# Altium schematic sheet 2_USB.SchDoc(2_USB)
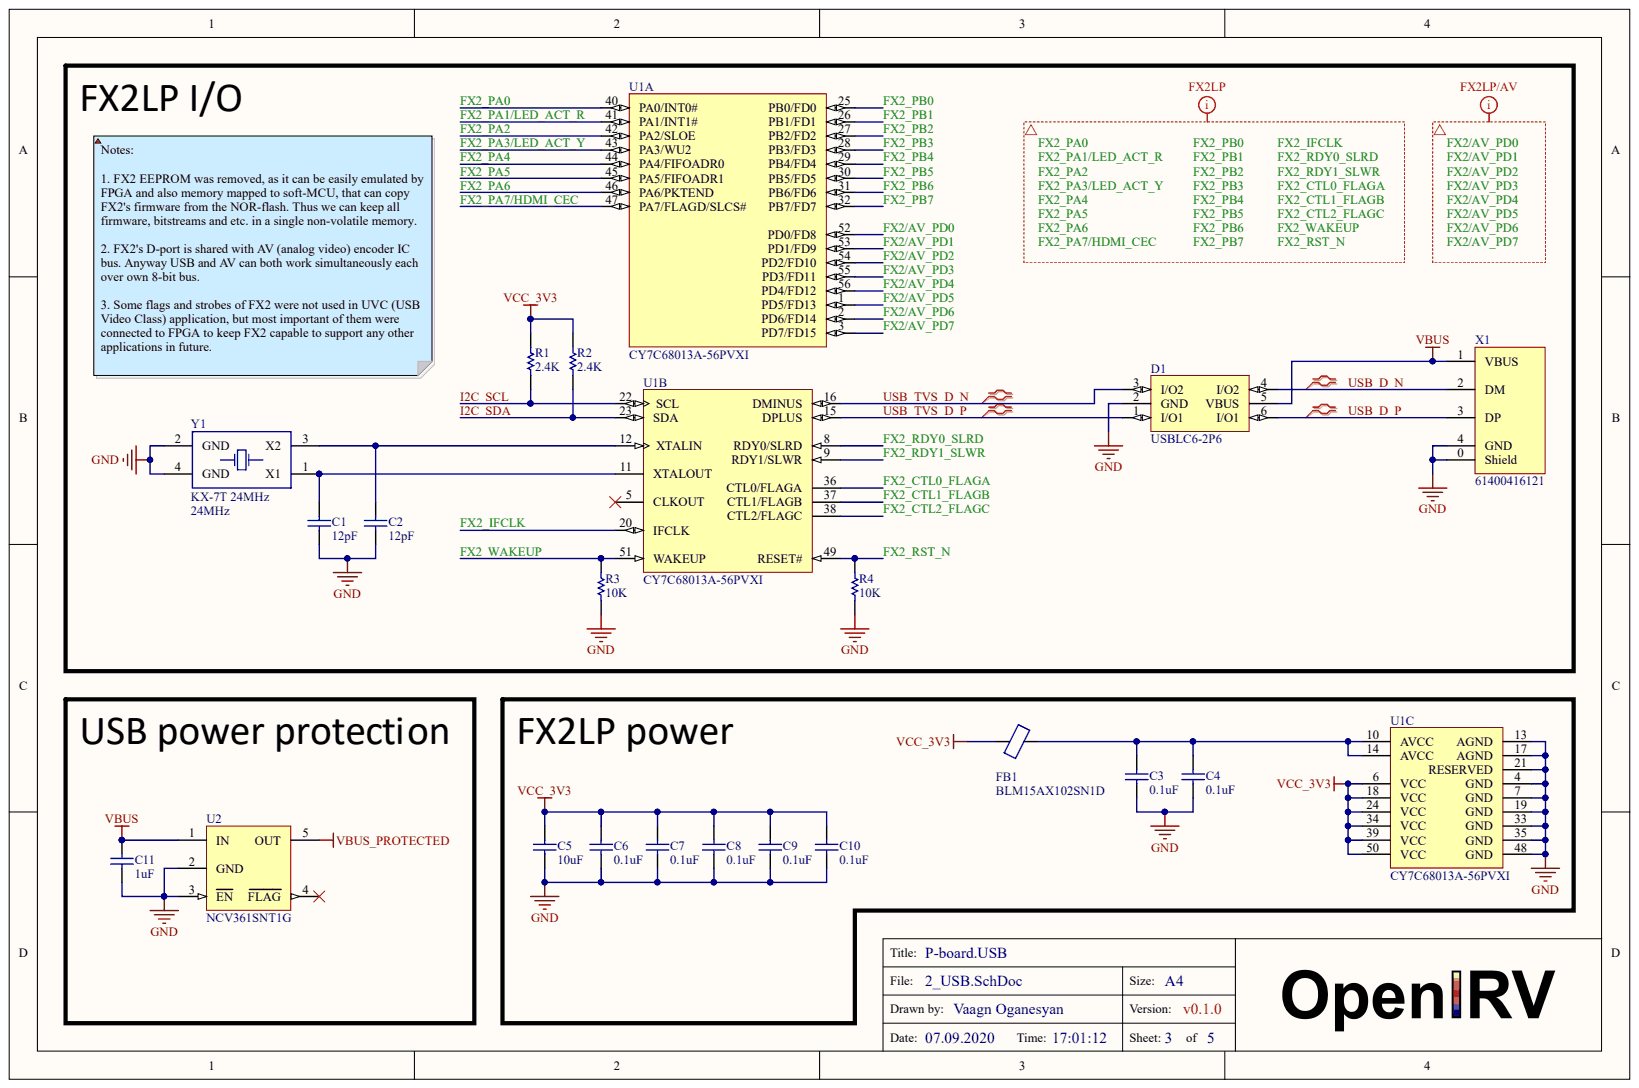
[diagram p0: 2_USB.SchDoc]

## Parts
C1  12pF  [C0402[1005]]
C2  12pF  [C0402[1005]]
C3  0.1uF  [C0402[1005]]
C4  0.1uF  [C0402[1005]]
C5  10uF  [C0805[2012]]
C6  0.1uF  [C0402[1005]]
C7  0.1uF  [C0402[1005]]
C8  0.1uF  [C0402[1005]]
C9  0.1uF  [C0402[1005]]
C10  0.1uF  [C0402[1005]]
C11  1uF  [C0603[1608]]
D1  USBLC6-2P6  [USBLC6-2P6]
FB1  BLM15AX102SN1D  [L0402[1005]]
R1  2.4K  [R0402[1005]]
R2  2.4K  [R0402[1005]]
R3  10K  [R0402[1005]]
R4  10K  [R0402[1005]]
U1  CY7C68013A-56PVXI  [CY7C68013A-56PVXI]  (parts A,B,C)
U2  NCV361SNT1G  [NCV361SNT1G]
X1  61400416121  [61400416121]
Y1  KX-7T 24MHz  Frequency: 24MHz  [Crystal[3225]]

## Nets
GND: C1.2 C2.2 C3.2 C4.2 C5.1 C6.2 C7.2 C8.2 C9.2 C10.2 C11.2 D1.2(GND) R3.1 R4.1 U1.4(GND) U1.7(GND) U1.13(AGND) U1.17(AGND) U1.19(GND) U1.21(RESERVED) U1.33(GND) U1.35(GND) U1.48(GND) U2.2(GND) U2.3(EN) X1.0(Shield) X1.4(GND) Y1.2(GND) Y1.4(GND)
VBUS: C11.1 D1.5(VBUS) U2.1(IN) X1.1(VBUS)
VBUS_PROTECTED: U2.5(OUT)
VCC_3V3: C5.2 C6.1 C7.1 C8.1 C9.1 C10.1 FB1.1 R1.2 R2.2 U1.6(VCC) U1.18(VCC) U1.24(VCC) U1.34(VCC) U1.39(VCC) U1.50(VCC)
FX2/AV_PD0: U1.52(PD0/FD8)
FX2/AV_PD1: U1.53(PD1/FD9)
FX2/AV_PD2: U1.54(PD2/FD10)
FX2/AV_PD3: U1.55(PD3/FD11)
FX2/AV_PD4: U1.56(PD4/FD12)
FX2/AV_PD5: U1.1(PD5/FD13)
FX2/AV_PD6: U1.2(PD6/FD14)
FX2/AV_PD7: U1.3(PD7/FD15)
FX2_CTL0_FLAGA: U1.36(CTL0/FLAGA)
FX2_CTL1_FLAGB: U1.37(CTL1/FLAGB)
FX2_CTL2_FLAGC: U1.38(CTL2/FLAGC)
FX2_IFCLK: U1.20(IFCLK)
FX2_PA0: U1.40(PA0/INT0#)
FX2_PA1/LED_ACT_R: U1.41(PA1/INT1#)
FX2_PA2: U1.42(PA2/SLOE)
FX2_PA3/LED_ACT_Y: U1.43(PA3/WU2)
FX2_PA4: U1.44(PA4/FIFOADR0)
FX2_PA5: U1.45(PA5/FIFOADR1)
FX2_PA6: U1.46(PA6/PKTEND)
FX2_PA7/HDMI_CEC: U1.47(PA7/FLAGD/SLCS#)
FX2_PB0: U1.25(PB0/FD0)
FX2_PB1: U1.26(PB1/FD1)
FX2_PB2: U1.27(PB2/FD2)
FX2_PB3: U1.28(PB3/FD3)
FX2_PB4: U1.29(PB4/FD4)
FX2_PB5: U1.30(PB5/FD5)
FX2_PB6: U1.31(PB6/FD6)
FX2_PB7: U1.32(PB7/FD7)
FX2_RDY0_SLRD: U1.8(RDY0/SLRD)
FX2_RDY1_SLWR: U1.9(RDY1/SLWR)
FX2_RST_N: R4.2 U1.49(RESET#)
FX2_WAKEUP: R3.2 U1.51(WAKEUP)
I2C_SCL: R1.1 U1.22(SCL)
I2C_SDA: R2.1 U1.23(SDA)
USB_D_N: D1.4(I/O2) X1.2(DM)
USB_D_P: D1.6(I/O1) X1.3(DP)
USB_TVS_D_N: D1.3(I/O2) U1.16(DMINUS)
USB_TVS_D_P: D1.1(I/O1) U1.15(DPLUS)
NetC1_1: C1.1 U1.11(XTALOUT) Y1.1(X1)
NetC2_1: C2.1 U1.12(XTALIN) Y1.3(X2)
NetC3_1: C3.1 C4.1 FB1.2 U1.10(AVCC) U1.14(AVCC)
N00159:
N00160:

Not connected: U1 5(CLKOUT); U2 4(FLAG)

## Library data of the parts
C1 C2 = CAP: Dielectric: NP0; Tolerance: 5%; Voltage: 50V; Component Kind: Standard; Library Name: general.IntLib; Mounting: SMD; Value: 12pF
C3 C4 C6-C10 = CAP: Dielectric: X7R; Tolerance: 10%; Voltage: 50V; Component Kind: Standard; Library Name: general.IntLib; Mounting: SMD; Value: 0.1uF
C5 = CAP: Dielectric: X7R; Tolerance: 10%; Voltage: 10V; Component Kind: Standard; Library Name: general.IntLib; Mounting: SMD; Value: 10uF
C11 = CAP: Dielectric: X7R; Tolerance: 10%; Voltage: 25V; Component Kind: Standard; Library Name: general.IntLib; Mounting: SMD; Value: 1uF
FB1 = Ferrite_bead: Current: 0.35A; DC resistance: 0R49; Impedance: 1000R; Component Kind: Standard; Library Name: general.IntLib; ManufacturerPartNumber: BLM15AX102SN1D; Mounting: SMD
R1-R4 = RES: Power: 0.06W; Tolerance: 5%; Component Kind: Standard; Library Name: general.IntLib; Mounting: SMD
  R1 R2: Value: 2.4K
  R3 R4: Value: 10K
U1 = CY7C68013A-56PVXI: EZ-USB(R) FX2LP(TM) USB Microcontroller High-Speed USB Peripheral Controller, 16 KB, 24 I/Os, 3 to 3.6 V, -40 to 85 degC, 56-Pin SSOP (PVX56), Tube; Manufacturer: Cypress; Max. Operating Voltage (V): 3.6; Memory Architecture: RAM; Memory Size (KB): 16; Min. Operating Voltage (V): 3; No. of I/Os: 24; Component Kind: Standard; ComponentLink1Description: Manufacturer URL; ComponentLink1URL: http://www.cypress.com/; ComponentLink2Description: Datasheet; ComponentLink2URL: http://www.cypress.com/?docID=45142; ComponentLink3Description: Package Specification; ComponentLink3URL: http://www.cypress.com/?docID=39259; CPU Core: Enhanced 8051; DatasheetVersion: Rev. *W; Library Name: general.IntLib; Max. Operating Temp. (degreesC): 85; Min. Operating Temp. (degreesC): -40; Mounting Technology: Surface Mount; PackageDescription: 56-Pin Shrunk Small Outline Package, Body 7.506 x 18.415 mm, Pitch 0.635 mm; PackageReference: PVX56; PackageVersion: Rev. *F, 08/2012; Packing: Tube; PartNumber: CY7C68013A-56PVXI; RoHS: Pb-Free; Supplier 1: Digi-Key; Supplier 2: Farnell; Supplier 3: Newark; Supplier 4: Mouser; Supplier Part Number 1: 428-1944-5-ND; Supplier Part Number 2: 1761053; Supplier Part Number 3: 19M7116; Supplier Part Number 4: 727-CYC68013A56PVXI
U2 = NCV361SNT1G: USB Positive Overvoltage/Overcurrent Protection; Component Kind: Standard; Library Name: general.IntLib
X1 = 61400416121: USB 2.0 type B; Component Kind: Standard; Library Name: general.IntLib
Y1 = Crystal: Manufacture: Geyer Electronic; Frequency stability: 100ppm; Frequency tolerance: 30ppm; LoadCapacitance: 12pF; Component Kind: Standard; Description: Crystal; Library Name: general.IntLib; ManufacturerPartNumber: KX-7T 24MHz; Mounting: SMD
D1 = USBLC6-2P6: Component Kind: Standard; Library Name: general.IntLib
